# Altium schematic sheet MAIN-UP.SchDoc
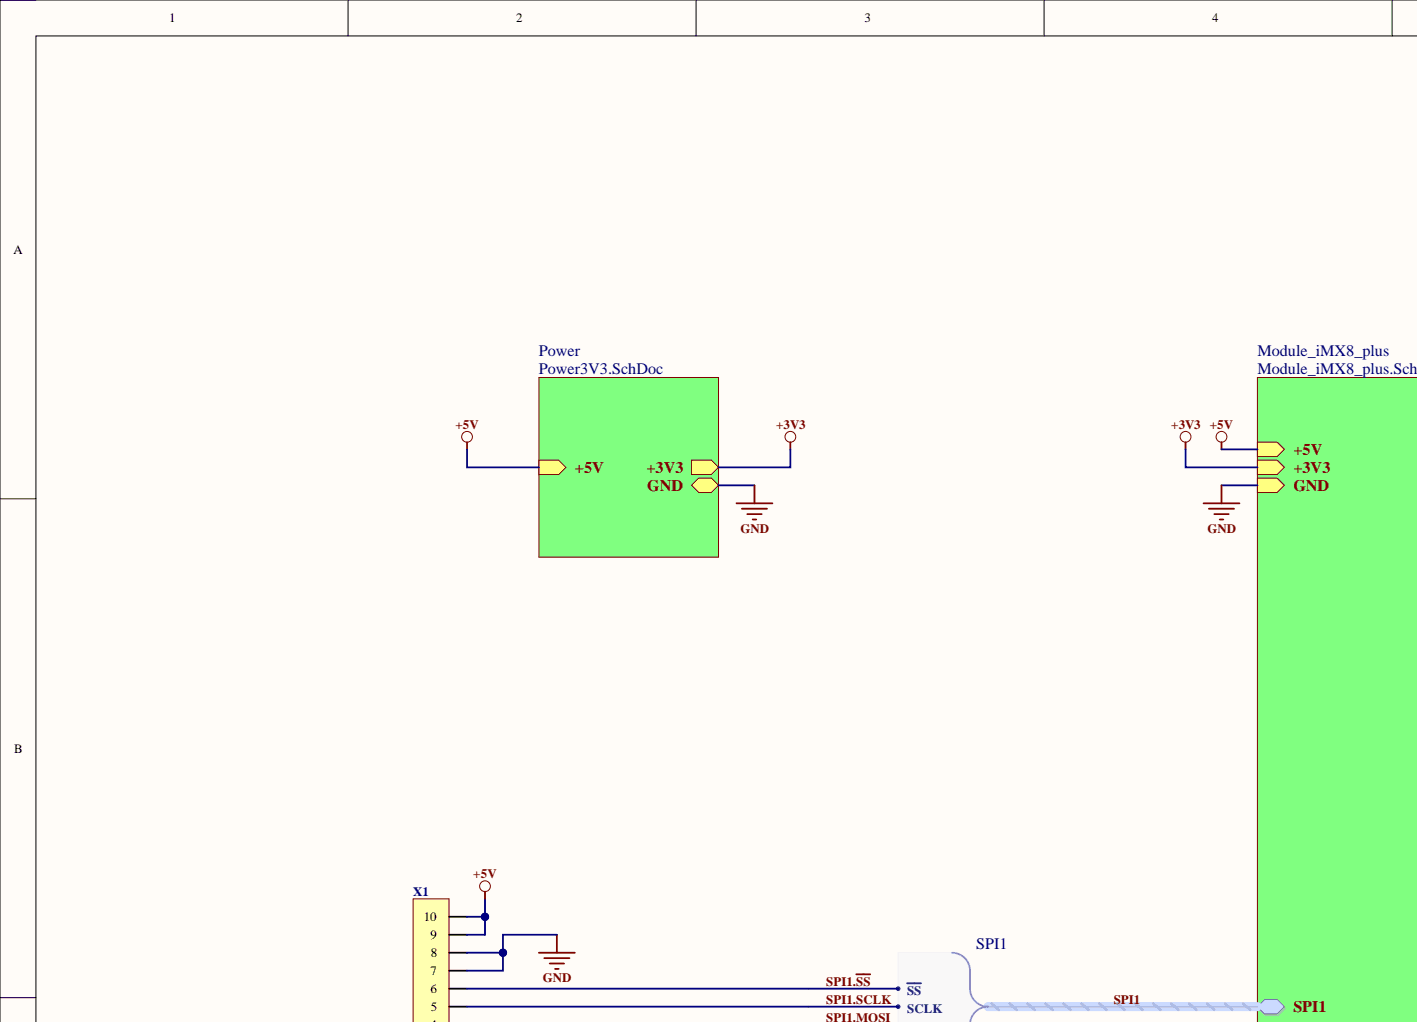
[diagram p0: MAIN-UP.SchDoc, top left]
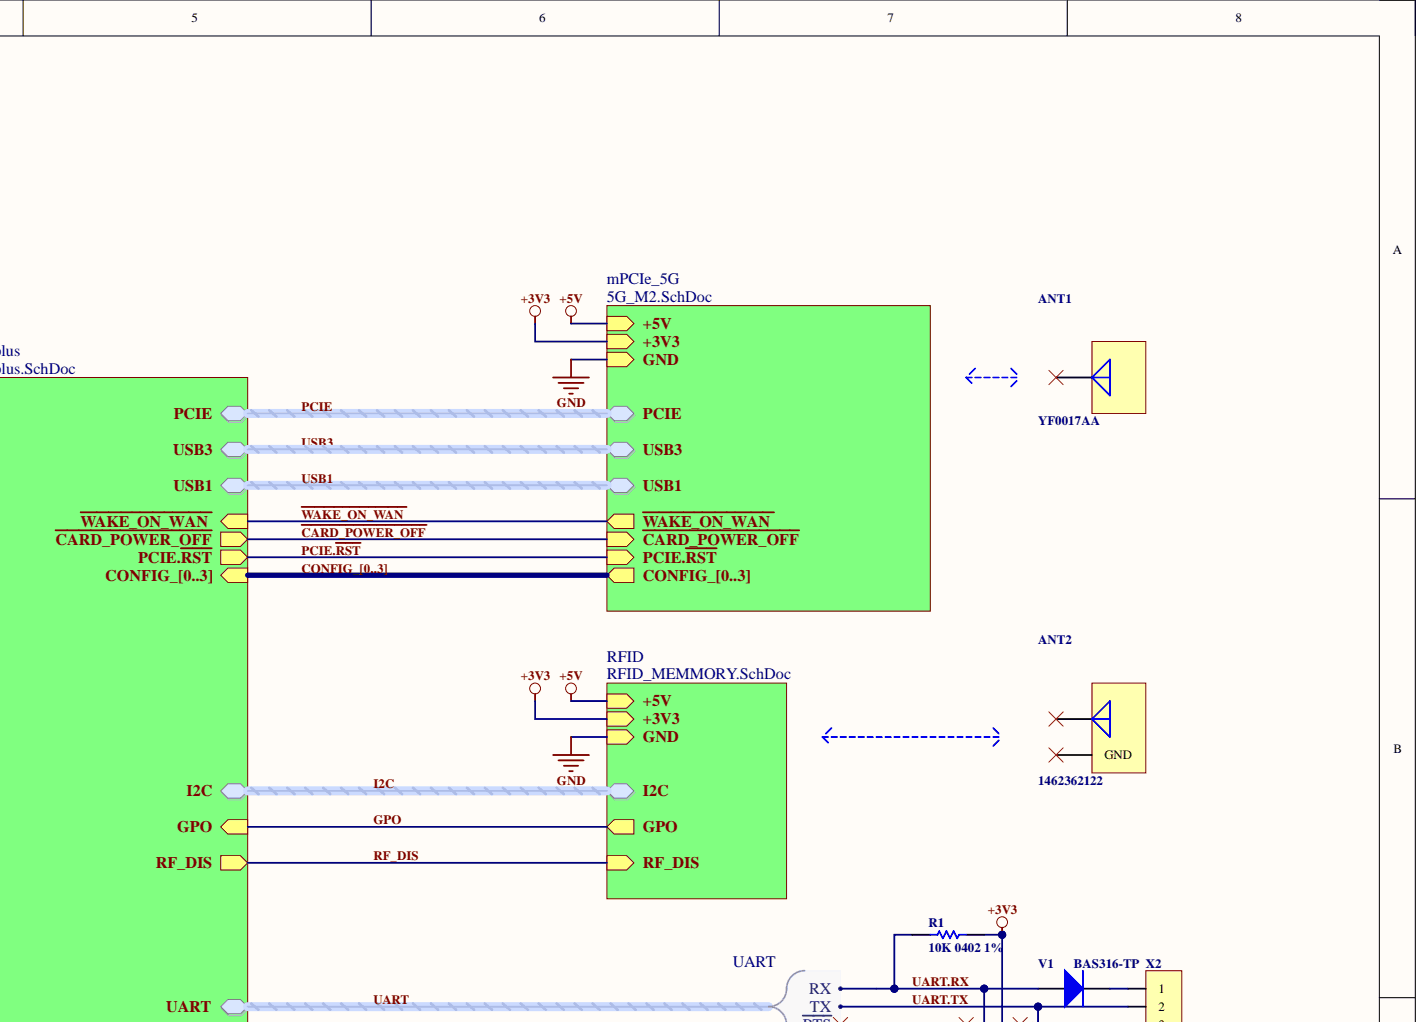
[diagram p1: MAIN-UP.SchDoc, top right]
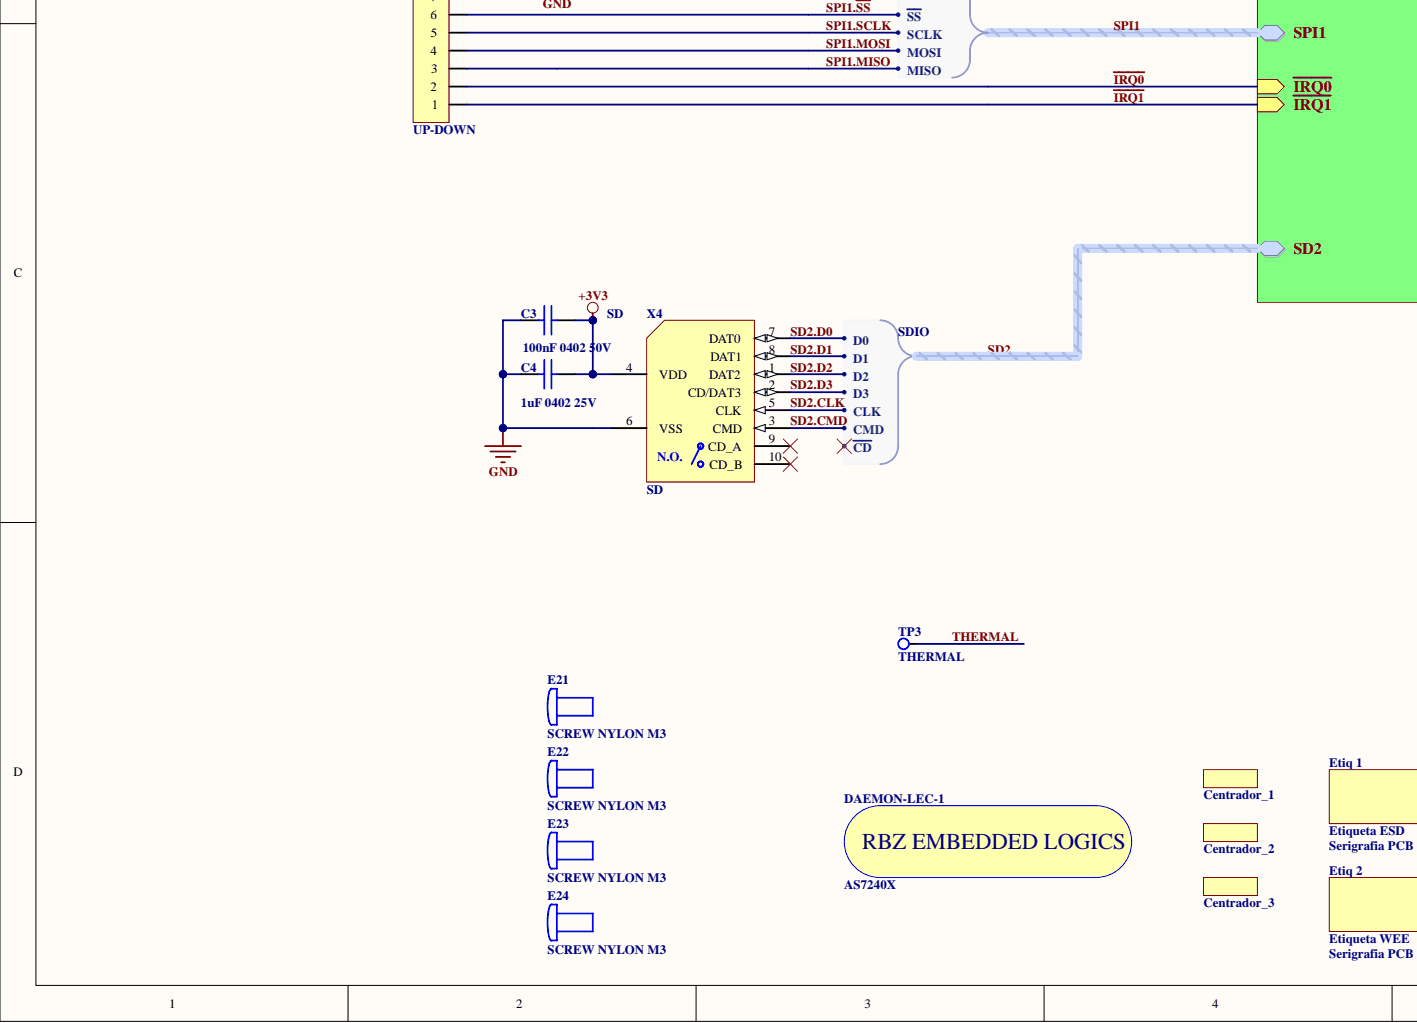
[diagram p2: MAIN-UP.SchDoc, bottom left]
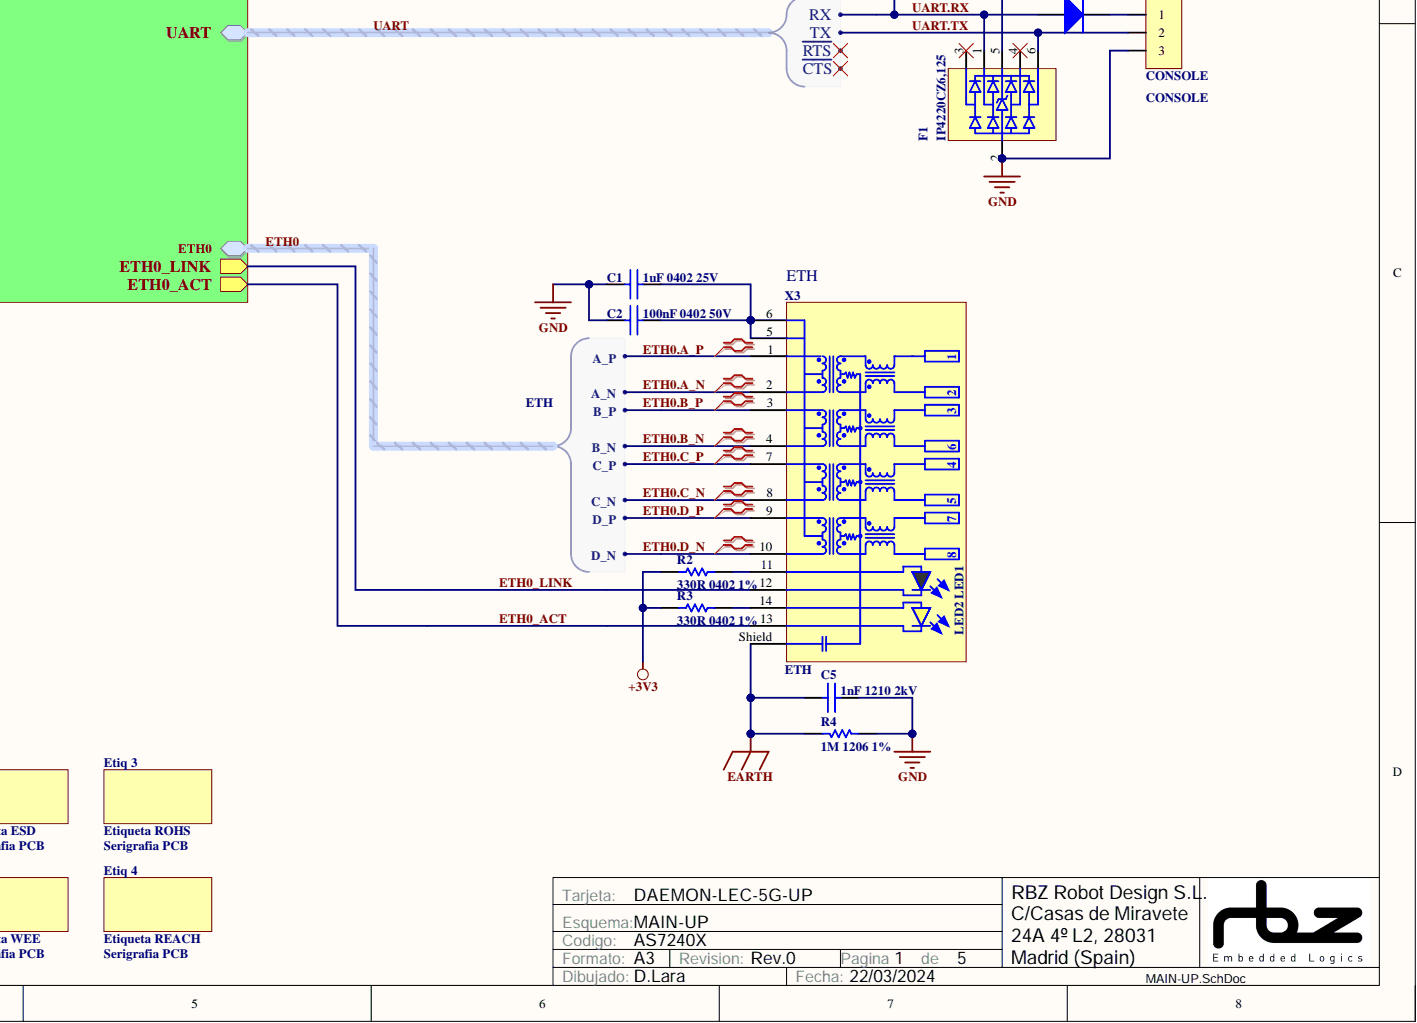
[diagram p3: MAIN-UP.SchDoc, bottom right]

## Parts
ANT1  YF0017AA  (mechanical)
ANT2  1462362122  (mechanical)
C1  1uF 0402 25V  [C0402(1005)-HIGH_DENSITY]
C2  100nF 0402 50V  [C0402(1005)-HIGH_DENSITY]
C3  100nF 0402 50V  [C0402(1005)-HIGH_DENSITY]
C4  1uF 0402 25V  [C0402(1005)-HIGH_DENSITY]
C5  1nF 1210 2kV  [C1210(3225)-HIGH_DENSITY]
Centrador_1  -  [Centrador 40mil]  (value not drawn)  (no BOM)
Centrador_2  -  [Centrador 40mil]  (value not drawn)  (no BOM)
Centrador_3  -  [Centrador 40mil]  (value not drawn)  (no BOM)
DAEMON-LEC-1  AS7240X  [Logo RBZ Micro]  (no BOM)
E21  SCREW NYLON M3  (mechanical)
E22  SCREW NYLON M3  (mechanical)
E23  SCREW NYLON M3  (mechanical)
E24  SCREW NYLON M3  (mechanical)
Etiq_1  Etiqueta ESD  value Serigrafia PCB  [ESD_Label_5x5]  (no BOM)
Etiq_2  Etiqueta WEE  value Serigrafia PCB  [WEEE_Label_7x10]  (no BOM)
Etiq_3  Etiqueta ROHS  value Serigrafia PCB  [ROHS_LABEL_10X10]  (no BOM)
Etiq_4  Etiqueta REACH  value Serigrafia PCB  [REACH_LABEL_10X16]  (no BOM)
F1  IP4220CZ6,125  [SOT26A-6N]
R1  10K 0402 1%  [R0402(1005)-HIGH_DENSITY]
R2  330R 0402 1%  [R0402(1005)-HIGH_DENSITY]
R3  330R 0402 1%  [R0402(1005)-HIGH_DENSITY]
R4  1M 1206 1%  [R1206(3216)-HIGH_DENSITY]
TP3  THERMAL  [PAD_0.8mm_Round_Multilayer]  (no BOM)
V1  BAS316-TP  [SOD-323]
X1  UP-DOWN  [Conex-2201-x101]
X2  CONSOLE  [CONEX-2556-x03x]
X3  ETH  [LPJG0846BBNL]
X4  SD  [DM3D-SF]

## Nets
+3V3: C3.1 C4.1 F1.5 R1.2 R2.1 R3.1 X4.4(VDD)
+5V: X1.9 X1.10
EARTH: C5.2 R4.2 X3.Shield
GND: C1.2 C2.2 C3.2 C4.2 C5.1 F1.2 R4.1 X1.7 X1.8 X2.3 X4.6(VSS)
~{IRQ0}: X1.2
~{IRQ1}: X1.1
ETH0.A_N: X3.2 X3.12 X3.13  [also labelled ETH0_ACT, ETH0_LINK]
ETH0.A_P: X3.1
ETH0.B_N: X3.4
ETH0.B_P: X3.3
ETH0.C_N: X3.8
ETH0.C_P: X3.7
ETH0.D_N: X3.10
ETH0.D_P: X3.9
SD2.CLK: X4.5(CLK)
SD2.CMD: X4.3(CMD)
SD2.D0: X4.7(DAT0)
SD2.D1: X4.8(DAT1)
SD2.D2: X4.1(DAT2)
SD2.D3: X4.2(CD/DAT3)
SPI1.MISO: X1.3
SPI1.MOSI: X1.4
SPI1.~{SS}: X1.6
SPI1.SCLK: X1.5
THERMAL: TP3.1
UART.RX: F1.1 R1.1 V1.1
UART.TX: F1.6 X2.2
NetANT1_1: ANT1.1
NetANT2_1: ANT2.1
NetANT2_2: ANT2.2
NetC1_1: C1.1 C2.1 X3.5 X3.6
NetF1_3: F1.3
NetF1_4: F1.4
NetR2_2: R2.2 X3.11
NetR3_2: R3.2 X3.14
NetV1_2: V1.2 X2.1
NetX4_9: X4.9(CD_A)
NetX4_10: X4.10(CD_B)
~{CARD_POWER_OFF}:
~{WAKE_ON_WAN}:
CONFIG_0:  [also labelled CONFIG_]
CONFIG_1:  [also labelled CONFIG_]
CONFIG_2:  [also labelled CONFIG_]
CONFIG_3:  [also labelled CONFIG_]
GPO:
I2C.SCL:
I2C.SDA:
PCIE.CLK_N:
PCIE.CLK_P:
PCIE.~{RST}:
PCIE.RX_N:
PCIE.RX_P:
PCIE.TX_N:
PCIE.TX_P:
RF_DIS:
SD2.~{CD}:
UART~{.}CTS:
UART~{.}RTS:
USB1.D_N:
USB1.D_P:
USB3.USB3_RX_N:
USB3.USB3_RX_P:
USB3.USB3_TX_N:
USB3.USB3_TX_P:

## Library data of the parts
ANT1 = YF0017AA: 829MHz, 1.228GHz, 1.505GHz, 1.94GHz, 2.43GHz, 3.65GHz, 3.75GHz, 4.5GHz, 5.5GHz 2G, 3G, 4G, 5G, LPWA Flat Patch RF Antenna 698MHz ~ 960MHz, 1.176GHz ~ 1.28GHz, 1.4GHz ~ 1.61GHz, 1.5GHz ~ 2.17GHz, 1.71GHz ~ 2.69GHz, 2.17GHz ~ 4GHz, 3.3GHz ~ 5GHZ, 4GHz ~ 6GHz, 5GHz ~ 6GHz 2.3dBi, 3.4dBi, 3.4dBi, 4.5dBi, 4.5dBi, 4.5dBi, 3.5dBi IPEX MHF4 Adhesive; Fabricante: Quectel; Inspected: False; Precio: 1.68€; Ref. Catalogo: 2958-YF0017AA-ND; Value: FLEX ANTENNA 1.5-6GHZ 193MM MHF4; Codigo RBZ: -; Distribuidor: -; Halogen Free: -; Montaje: -; REACH: -; Referencia: YF0017AA; RoHS: True; Type: Antenna; HelpURL: S:\antenas\datasheets\Quectel_Antenna_YF0017AA_Datasheet_V1.2.pdf; Library Name: antenas.SchLib
ANT2 = 1462362122: NFC COIL 34X46MM WITH AWG28 WIRE; Fabricante: Molex; Inspected: False; Precio: 7.25€; Ref. Catalogo: (D) 900-1462362122-ND; Value: RFID Antenna 13.56MHz; Codigo RBZ: -; Distribuidor: -; Halogen Free: -; Montaje: -; REACH: -; Referencia: 1462362122; RoHS: True; Type: Antenna; HelpURL: S:\antenas\datasheets\1462362122.pdf; Library Name: antenas.SchLib
C1 C4 = CC0402X5RK025V001U: Condensador ceramico genérico 0402 ±10% X5R -105/85°C 25V 1uF; Fabricante: Murata; Inspected: False; Precio: 0,09€; Precio Prod.: 0,017€ @1000; Ref. Catalogo: (D) 490-10017-1-ND; Referencia: GRM155R61E105KA12D; Type: Capacitor; Codigo RBZ: CC0402X5RK025V001U; Component Kind: Standard; Distribuidor: -; Halogen Free: -; Library Name: Capacitor_Generic_Ceramic.SchLib; Montaje: -; REACH: -; RoHS: True; Value: 1uF 0402 25V; HelpURL: S:\Condensadores\Datasheets\Murata_c02e.pdf
C2 C3 = CC0402X7RK050V100N: Condensador ceramico genérico 0402 ±10% X7R -55/125°C 50V 100nF; Fabricante: Murata; Inspected: False; Precio: 0,09€; Precio Prod.: 0,009€ @1000; Ref. Catalogo: (D) 490-10700-1-ND; Referencia: GRM155R71H104KE14D; Type: Capacitor; Codigo RBZ: CC0402X7RK050V100N; Component Kind: Standard; Distribuidor: -; Halogen Free: -; Library Name: Capacitor_Generic_Ceramic.SchLib; Montaje: -; REACH: -; RoHS: True; Value: 100nF 0402 50V; HelpURL: S:\Condensadores\Datasheets\Murata c02e.pdf
C5 = CC1210X7RK02KV001N: Condensador cerámico genérico 1210 ±10% X7R -55/125º 2kV 1nF; Fabricante: Johanson; Inspected: True; Precio: 0,39€; Precio Prod.: 0,18€ @500; Ref. Catalogo: (D) 709-1040-1-ND; Referencia: 202S41W102KV4E; Type: Capacitor; Codigo RBZ: CC1210X7RK02KV001N; Component Kind: Standard; Distribuidor: -; Halogen Free: -; Library Name: Capacitor_Generic_Ceramic.SchLib; Montaje: -; REACH: -; RoHS: True; Value: 1nF 1210 2kV; HelpURL: S:\Condensadores\Datasheets\Johanson_P1881.pdf
Centrador_1-Centrador_3 = Centrador 40mil: Centrador para montaje de 40mil. Con antisolder; Codigo RBZ: COMP_IN_PCB; Fabricante: PCB; Inspected: True; Distribuidor: -; Halogen Free: True; HelpURL: -; Montaje: -; Precio: -; Precio Prod.: -; REACH: True; Ref. Catalogo: -; Referencia: -; RoHS: True; Type: Fiducial; Value: -; Library Name: Marcas Centrado.SchLib
DAEMON-LEC-1 = Logo RBZ: Logotipo RBZ e identificadores electronica; Library Name: Logotipos.SchLib
E21-E24 = Tornillo NYLON M3x6MM: Fabricante: Keystone Electronics; Inspected: False; Precio: 0,12€; Precio Prod.: 0,05€@1000; Ref. Catalogo: 9427; Referencia: 36-9427-ND; Codigo RBZ: -; Description: Tornillo NYLON M3x6MM; Distribuidor: -; Halogen Free: -; HelpURL: -; Montaje: -; REACH: -; RoHS: -; Type: Screw; Value: SCREW NYLON M3; Library Name: Tornillos.SchLib
Etiq_1 = ESD_Serigrafia 5x5: Etiqueta Serigrafia ESD; Inspected: False; Value: Serigrafia PCB; Codigo RBZ: -; Distribuidor: -; Fabricante: -; Halogen Free: True; Montaje: -; Precio Prod.: -; REACH: True; Ref. Catalogo: -; Referencia: -; RoHS: True; Serigrafia: -; Type: Tag; Library Name: Etiquetas.SchLib
Etiq_2 = WEEE_Serigrafia 7x10: Etiqueta Serigrafia WEEE; Inspected: False; Codigo RBZ: -; Distribuidor: -; Fabricante: -; Halogen Free: True; Montaje: -; Precio Prod.: -; REACH: True; Ref. Catalogo: -; Referencia: -; RoHS: True; Serigrafia: -; Type: Tag; Library Name: Etiquetas.SchLib
Etiq_3 = ROHS_Serigrafia 10x10: Etiqueta Serigrafia ROHS; Inspected: False; Value: Serigrafia PCB; Codigo RBZ: -; Distribuidor: -; Fabricante: -; Halogen Free: True; Montaje: -; Precio Prod.: -; REACH: True; Ref. Catalogo: -; Referencia: -; RoHS: True; Serigrafia: -; Type: Tag; Library Name: Etiquetas.SchLib
Etiq_4 = REACH_Serigrafia 10x16: Etiqueta Serigrafia REACH; Inspected: False; Codigo RBZ: -; Distribuidor: -; Fabricante: -; Halogen Free: True; Montaje: -; Precio Prod.: -; REACH: True; Ref. Catalogo: -; Referencia: -; RoHS: True; Serigrafia: -; Type: Tag; Library Name: Etiquetas.SchLib
F1 = IP4220CZ6,125: USB DUAL ESD PROTECT 6TSOP; Fabricante: NXP; Precio: 0,44€; Precio Prod.: 0,12€ @1000; Ref. Catalogo: (D) 568-3529-1-ND; Component Kind: Standard; Distribuidor: -; Montaje: -; Referencia: IP4220CZ6,125; Value: USB DUAL ESD PROTECT 6TSOP; HelpURL: S:\Protecciones\datasheets\NXP IP4220CZ6_4.pdf; Library Name: Protecciones ESD.SchLib
R1 = R0402F010K: Resistencia genérica 0402 1% 10K; Fabricante: Vishay; Inspected: True; Precio: 0,08€; Precio Prod.: 0,0041€ @1000; Ref. Catalogo: (D) 541-3959-1-ND; Referencia: CRCW040210K0FKEDC; Codigo RBZ: R0402F010K; Component Kind: Standard; Distribuidor: -; Halogen Free: -; Library Name: Resistor_Generic.SchLib; Montaje: -; REACH: -; RoHS: True; Type: Resistor; Value: 10K 0402 1%; HelpURL: S:\Resistencias\Datasheets\Vishay_crcwce3.pdf
R2 R3 = R0402F330R: Resistencia genérica 0402 1% 330R; Fabricante: Panasonic; Inspected: False; Precio: 0,08€; Precio Prod.: 0,0047€ @5000; Ref. Catalogo: (D) P330LCT-ND; Referencia: ERJ-2RKF3300X; Codigo RBZ: R0402F330R; Component Kind: Standard; Distribuidor: -; Halogen Free: -; Library Name: Resistor_Generic.SchLib; Montaje: -; REACH: -; RoHS: True; Type: Resistor; Value: 330R 0402 1%; HelpURL: S:\Resistencias\Datasheets\AOA0000C304.pdf
R4 = R1206F001M: Resistencia genérica 1206 1% 1M; Fabricante: Vishay; Inspected: True; Precio: 0,08€; Precio Prod.: 0,011€ @1000; Ref. Catalogo: (D) 541-1.00MFCT-ND; Referencia: CRCW12061M00FKEA; Codigo RBZ: R1206F001M; Component Kind: Standard; Distribuidor: -; Halogen Free: -; Library Name: Resistor_Generic.SchLib; Montaje: -; REACH: -; RoHS: True; Type: Resistor; Value: 1M 1206 1%; HelpURL: S:\Resistencias\Datasheets\VIS_crcw.pdf
TP3 = TestPoint_Pad_Multilayer: TestPoint Pad; Codigo RBZ: COMP_IN_PCB; Fabricante: PCB; Inspected: True; Value: TestPoint_Pad_SMD; Distribuidor: -; Halogen Free: True; HelpURL: -; Library Name: TestPoints.SchLib; Montaje: -; Precio: -; Precio Prod.: -; REACH: -; Ref. Catalogo: -; Referencia: -; RoHS: True; Serigrafia: THERMAL; Type: Test_Point
V1 = BAS316-TP: DIODE GEN PURP 100V 250MA SOD323; Codigo RBZ: MCC_BAS316-TP; Fabricante: MCC; Inspected: False; Precio: 0,20€; Ref. Catalogo: (D) BAS316-TPMSCT-ND; Component Kind: Standard; Distribuidor: -; Halogen Free: -; Library Name: diodos.SchLib; Montaje: -; Precio Prod.: -; REACH: -; Referencia: BAS316-TP; RoHS: -; Type: Diode; Value: 100V 250mA; HelpURL: S:\Diodos\datasheets\BAS316(SOD-323).pdf
X1 = CON 2x5 Hembra Recto 2.54mm Altura 8,5mm Insercion: Conector 2x5 Hembra Recto 2.54mm Altura 8.5mm Insercion; Codigo RBZ: CON_; Fabricante: Conexcon; Inspected: False; Referencia: 2201-3101; Value: Con 2x5 Hembra Recto 2.54mm Insercion; Component Kind: Standard; Distribuidor: Cenvalsa; Halogen Free: -; Library Name: Conexcon.SchLib; Montaje: -; Precio: -; Precio Prod.: -; REACH: -; RoHS: -; Serigrafia: UP-DOWN; Type: Connector; HelpURL: S:\Conectores\Datasheets\Conexcon Serie 2201.pdf
X2 = Con 3Pin 2.54: Jumper PCB 3pin paso 2.54; Codigo RBZ: CON_2556-3031; Fabricante: Conexcon; Inspected: False; Referencia: 2556-3031; Value: Con 3p 2.54; Component Kind: Standard; Distribuidor: Cenvalsa; Halogen Free: -; Library Name: Conexcon.SchLib; Montaje: -; Precio: -; Precio Prod.: -; REACH: -; Ref. Catalogo: -; RoHS: -; Serigrafia: CONSOLE; Type: Connector; HelpURL: S:\Conectores\datasheets\Conexcon serie 2556.pdf
X3 = LPJG0846BBNL: RJ45 1x1 w/ Tab Down,Through Hole  10/100/1000 Base-T w/ LED; Alternativa: KJR-204GYLNL (DGKYD); YKGD-8010NL INGKE); RMS-048D6-10A0-GY (PHC); 7706-400121 (CONEXCON); Codigo RBZ: LPP_LPJG0846BBNL; Fabricante: Link-PP; Inspected: False; Component Kind: Standard; Distribuidor: -; Halogen Free: -; Library Name: Conectores_Link-PP.SchLib; Montaje: -; Precio: -; Precio Prod.: -; REACH: -; Ref. Catalogo: -; Referencia: LPJG0846BBNL; RoHS: Rohs; Serigrafia: ETH; Type: Connector; Value: RJ45 1x1 w/ Tab Down,Through Hole; HelpURL: S:\Conectores\datasheets\Link-PP_LPJG0846BBNL.PDF
X4 = DM3D-SF: CONN MICRO SD CARD PUSH-PUSH R/A; Codigo RBZ: HIR_DM3D-SF; Fabricante: Hirose; Inspected: False; Precio: 1.35€; Precio Prod.: 0.884€ @500; Ref. Catalogo: (M) 798-DM3D-SF; Component Kind: Standard; Distribuidor: -; Halogen Free: -; Library Name: Hirose.SchLib; Montaje: -; REACH: -; Referencia: DM3D-SF; RoHS: True; Serigrafia: SD; Type: Connector; Value: CONN MICRO SD CARD PUSH-PUSH R/A; HelpURL: S:\Conectores\datasheets\DM3_catalog-939223.pdf
